# Altium schematic sheet [5]-MCU_CONNECTORS.SchDoc([5]-MCU_CONNECTORS)
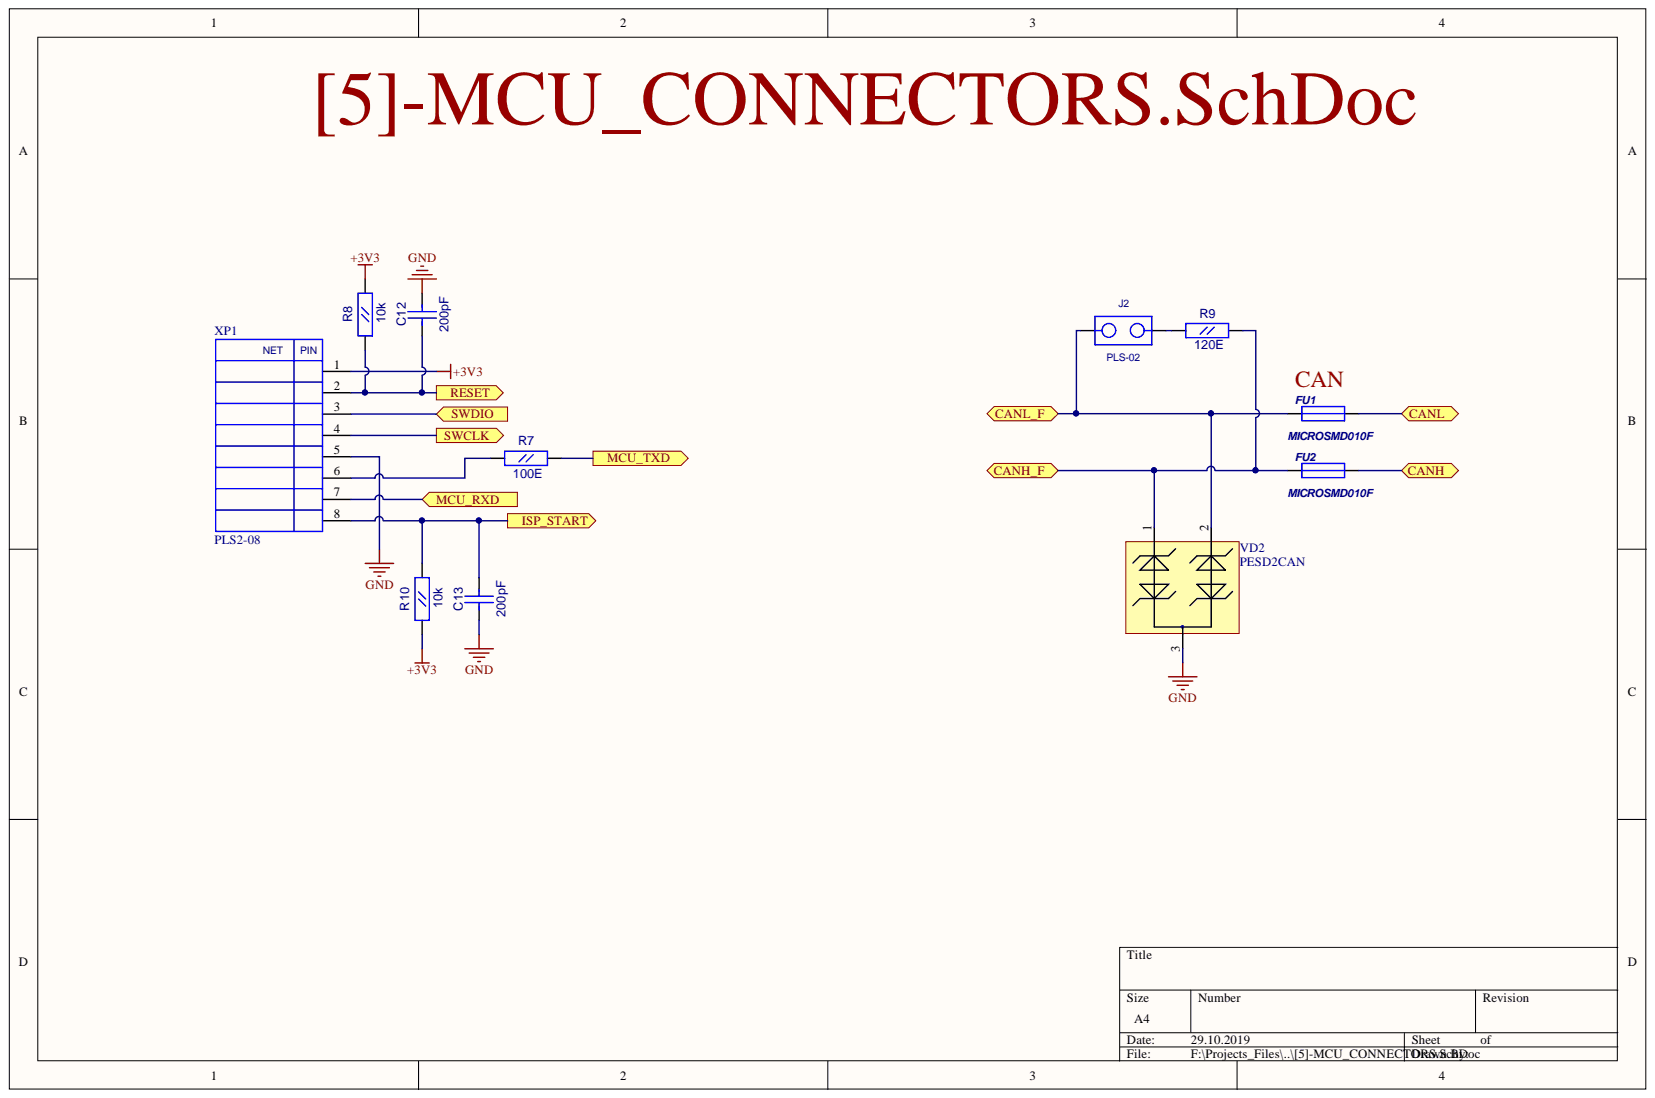
[diagram p0: [5]-MCU_CONNECTORS.SchDoc]

## Parts
C12  200pF  [CC0603]
C13  200pF  [CC0603]
FU1  MICROSMD010F  [RC1210]
FU2  MICROSMD010F  [RC1210]
J2  PLS-02  [PLS-02]
R7  100E  [RC0603]
R8  10k  [RC0603]
R9  120E  [RC0805]
R10  10k  [RC0603]
VD2  PESD2CAN  [SOT-23(123)]
XP1  PLS2-08  [PLS2-08]

## Ports
CANH, CANH_F, CANL, CANL_F, ISP_START, MCU_RXD, MCU_TXD, RESET, SWCLK, SWDIO

## Nets
+3V3: R8.2 R10.1 XP1.1
GND: C12.2 C13.1 VD2.3 XP1.5
CANH: FU2.2 ; port CANH
CANH_F: FU2.1 R9.2 VD2.1 ; port CANH_F
CANL: FU1.2 ; port CANL
CANL_F: FU1.1 J2.1 VD2.2 ; port CANL_F
ISP_START: C13.2 R10.2 XP1.8 ; port ISP_START
MCU_RXD: XP1.7 ; port MCU_RXD
MCU_TXD: R7.2 ; port MCU_TXD
RESET: C12.1 R8.1 XP1.2 ; port RESET
SWCLK: XP1.4 ; port SWCLK
SWDIO: XP1.3 ; port SWDIO
NetJ2_2: J2.2 R9.1
NetR7_1: R7.1 XP1.6

## Library data of the parts
C12 C13 = CC0603: Value: 200pF; Description: CC0603; Component Kind: Standard; Library Name: gprs_modem_2.SchLib
FU1 FU2 = MICROSMD: Description: MICROSMD; Component Kind: Standard; Library Name: gprs_modem_2.SchLib
R7 R8 R10 = RC0603: Description: RC0603; Component Kind: Standard; Library Name: gprs_modem_2.SchLib
  R7: Value: 100E
  R8 R10: Value: 10k
R9 = RC0805: Value: 120E; Description: RC0805; Component Kind: Standard; Library Name: fizik_lib.SchLib
VD2 = PESD2CAN: TVS for CAN; Component Kind: Standard; Library Name: gprs_modem_2.SchLib
J2 = PLS-02: Description: PLS-02; Component Kind: Standard; Library Name: fizik_lib.SchLib
XP1 = PLS2-08: Description: PLS2-08; Component Kind: Standard; Library Name: fizik_lib.SchLib
